# Altium schematic sheet Amp_op3.SchDoc(Amp_op3)
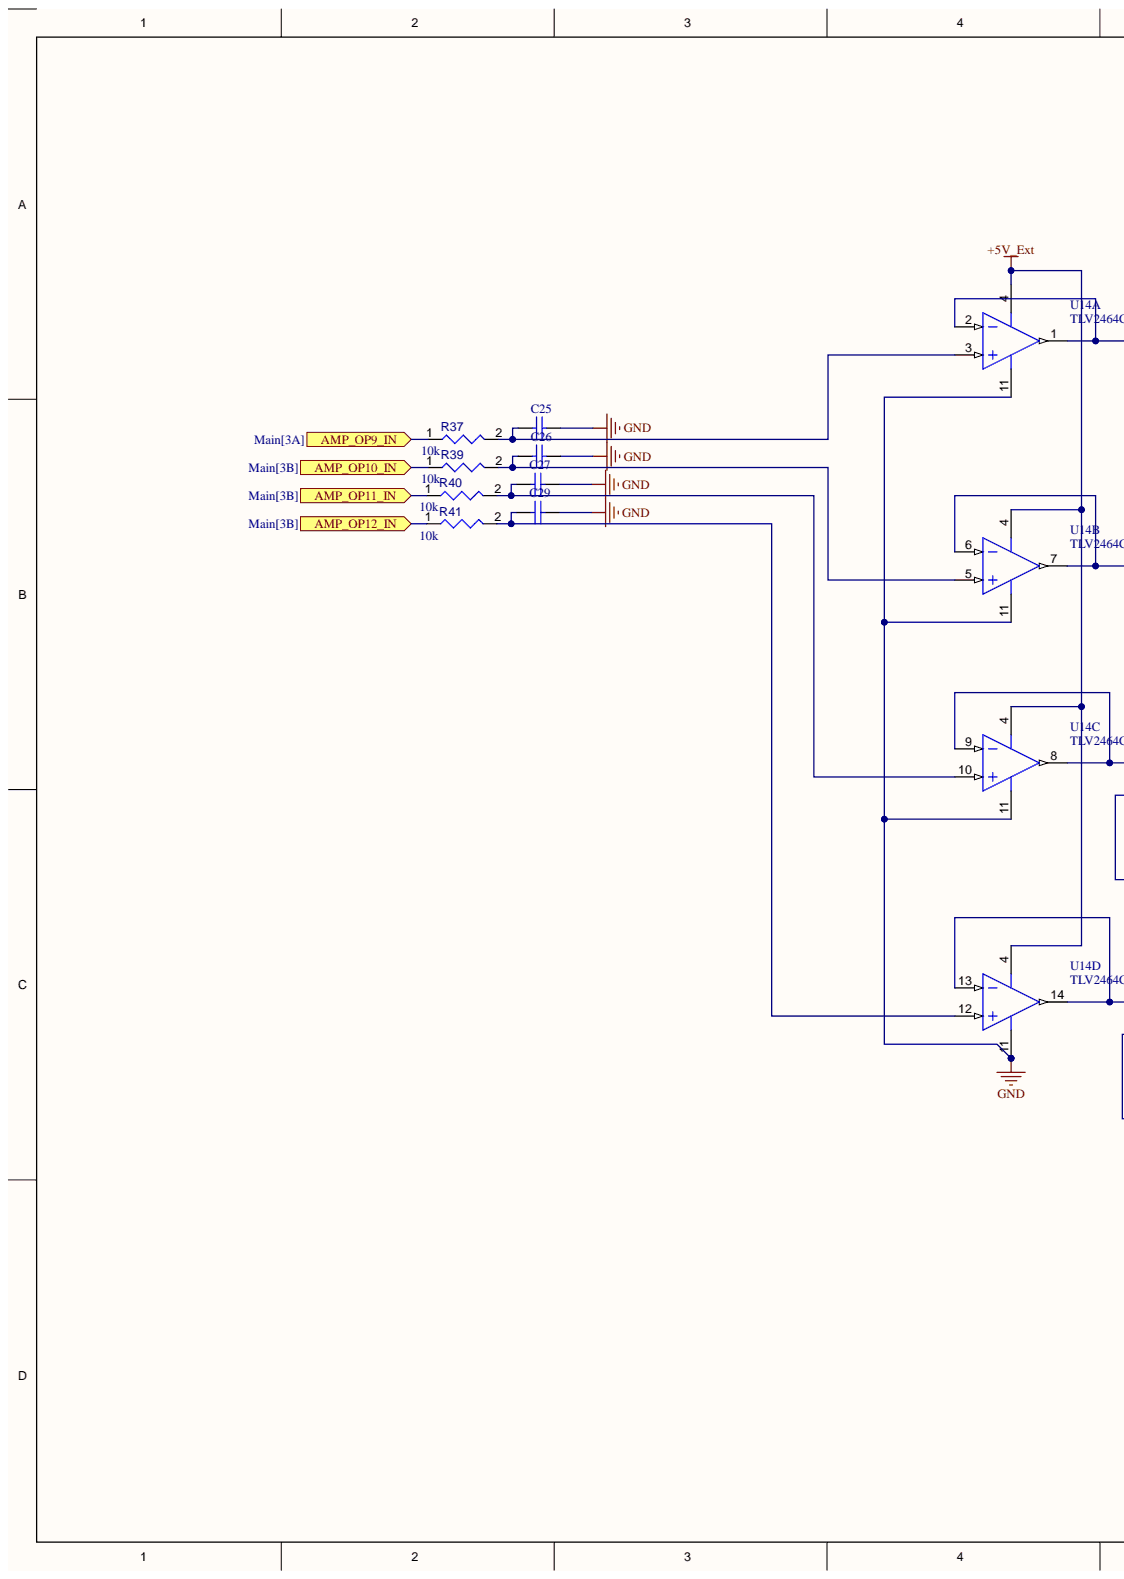
[diagram p0: Amp_op3.SchDoc, left]
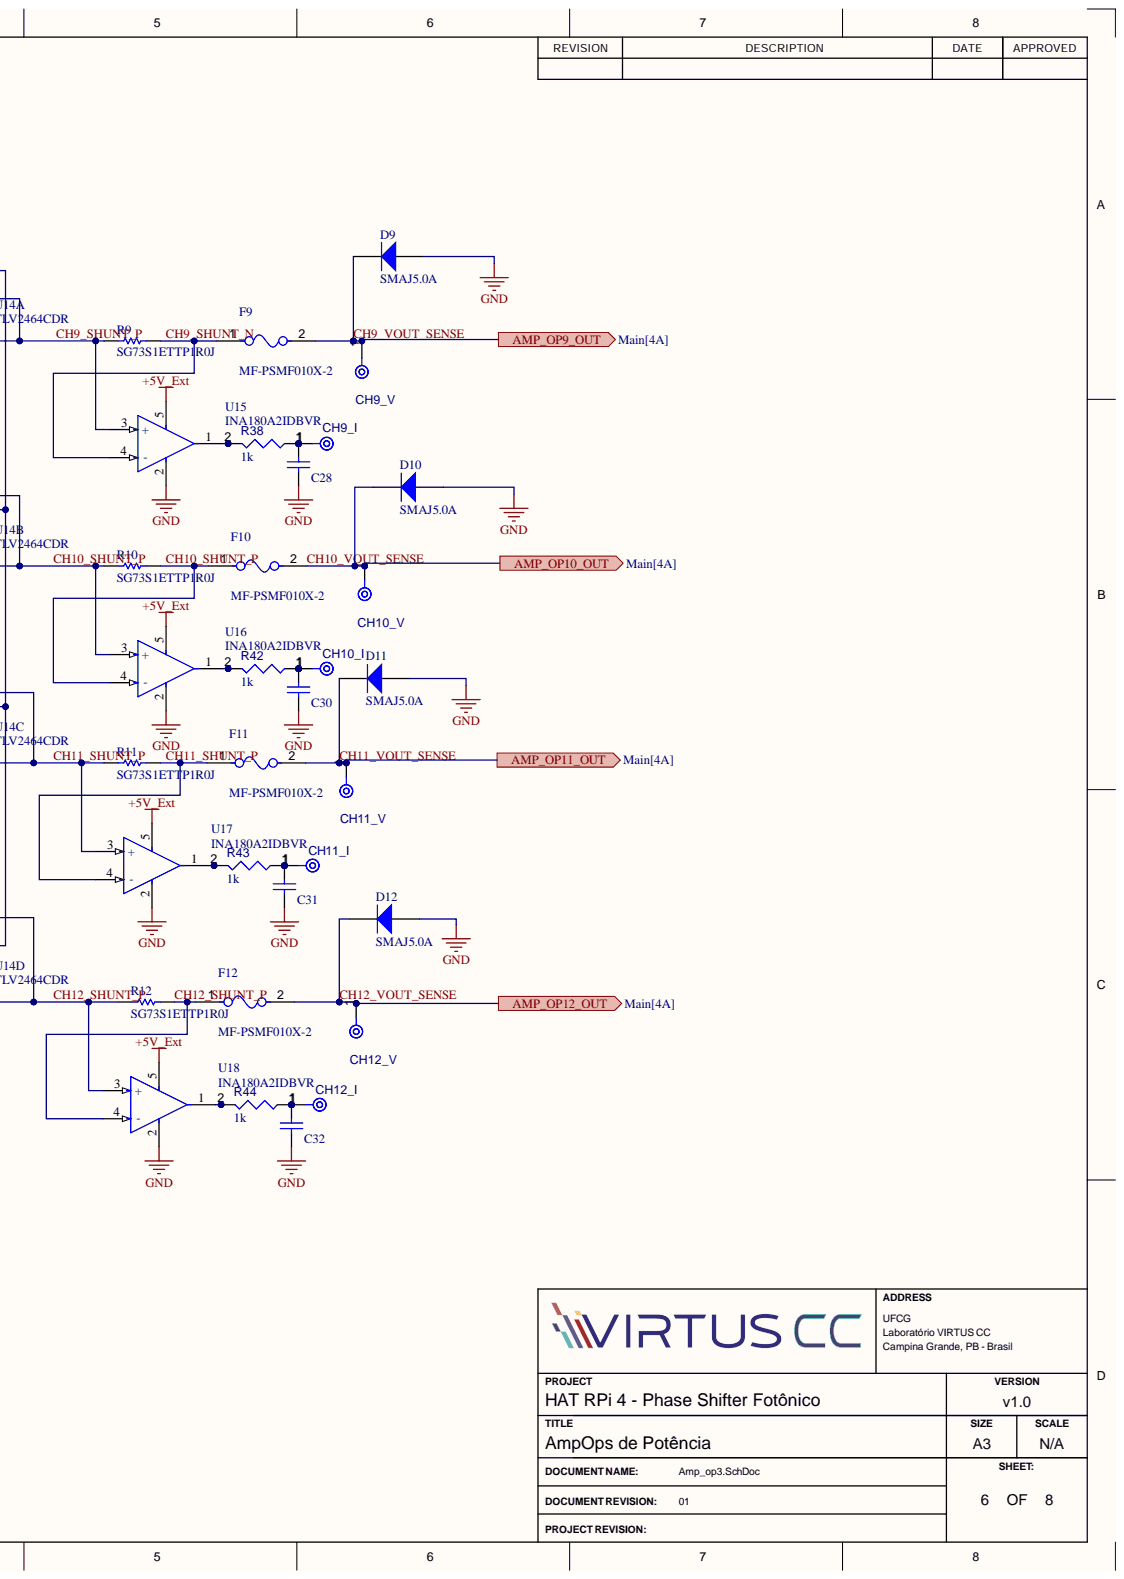
[diagram p1: Amp_op3.SchDoc, right]

## Parts
C25  VJ0603Y104MXJCW1BC  [CAPC1608X95X40NL15T15]  (value not drawn)
C26  VJ0603Y104MXJCW1BC  [CAPC1608X95X40NL15T15]  (value not drawn)
C27  VJ0603Y104MXJCW1BC  [CAPC1608X95X40NL15T15]  (value not drawn)
C28  VJ0603Y104MXJCW1BC  [CAPC1608X95X40NL15T15]  (value not drawn)
C29  VJ0603Y104MXJCW1BC  [CAPC1608X95X40NL15T15]  (value not drawn)
C30  VJ0603Y104MXJCW1BC  [CAPC1608X95X40NL15T15]  (value not drawn)
C31  VJ0603Y104MXJCW1BC  [CAPC1608X95X40NL15T15]  (value not drawn)
C32  VJ0603Y104MXJCW1BC  [CAPC1608X95X40NL15T15]  (value not drawn)
CH9_I  TPAD6R  [TP06R]  (value not drawn)  (no BOM)
CH9_V  TPAD6R  [TP06R]  (value not drawn)  (no BOM)
CH10_I  TPAD6R  [TP06R]  (value not drawn)  (no BOM)
CH10_V  TPAD6R  [TP06R]  (value not drawn)  (no BOM)
CH11_I  TPAD6R  [TP06R]  (value not drawn)  (no BOM)
CH11_V  TPAD6R  [TP06R]  (value not drawn)  (no BOM)
CH12_I  TPAD6R  [TP06R]  (value not drawn)  (no BOM)
CH12_V  TPAD6R  [TP06R]  (value not drawn)  (no BOM)
D9  SMAJ5.0A  [BOUR-SMA-2_V]
D10  SMAJ5.0A  [BOUR-SMA-2_V]
D11  SMAJ5.0A  [BOUR-SMA-2_V]
D12  SMAJ5.0A  [BOUR-SMA-2_V]
F9  MF-PSMF010X-2  [FP-MF-PSMF-MFG]
F10  MF-PSMF010X-2  [FP-MF-PSMF-MFG]
F11  MF-PSMF010X-2  [FP-MF-PSMF-MFG]
F12  MF-PSMF010X-2  [FP-MF-PSMF-MFG]
R9  SG73S1ETTP1R0J  [RESC1005X40X25LL10T10]
R10  SG73S1ETTP1R0J  [RESC1005X40X25LL10T10]
R11  SG73S1ETTP1R0J  [RESC1005X40X25LL10T10]
R12  SG73S1ETTP1R0J  [RESC1005X40X25LL10T10]
R37  value 10k  [RESC0603(1608)_L]  (hidden comment: Resistor 10k +/-1% 0603 100 mW)
R38  value 1k  [RESC0603(1608)_L]  (hidden comment: Resistor 1k +/-1% 0603 100 mW)
R39  value 10k  [RESC0603(1608)_L]  (hidden comment: Resistor 10k +/-1% 0603 100 mW)
R40  value 10k  [RESC0603(1608)_L]  (hidden comment: Resistor 10k +/-1% 0603 100 mW)
R41  value 10k  [RESC0603(1608)_L]  (hidden comment: Resistor 10k +/-1% 0603 100 mW)
R42  value 1k  [RESC0603(1608)_L]  (hidden comment: Resistor 1k +/-1% 0603 100 mW)
R43  value 1k  [RESC0603(1608)_L]  (hidden comment: Resistor 1k +/-1% 0603 100 mW)
R44  value 1k  [RESC0603(1608)_L]  (hidden comment: Resistor 1k +/-1% 0603 100 mW)
U14  TLV2464CDR  [D0014A_N]  (parts A,B,C,D)
U15  INA180A2IDBVR  [FP-DBV0005A-MFG]
U16  INA180A2IDBVR  [FP-DBV0005A-MFG]
U17  INA180A2IDBVR  [FP-DBV0005A-MFG]
U18  INA180A2IDBVR  [FP-DBV0005A-MFG]

## Ports
AMP_OP9_IN, AMP_OP9_OUT, AMP_OP10_IN, AMP_OP10_OUT, AMP_OP11_IN, AMP_OP11_OUT, AMP_OP12_IN, AMP_OP12_OUT

## Nets
+5V_Ext: U14.4 U15.5 U16.5 U17.5 U18.5
GND: C25.2 C26.2 C27.2 C28.2 C29.2 C30.2 C31.2 C32.2 D9.1 D10.1 D11.1 D12.1 U14.11 U15.2 U16.2 U17.2 U18.2
AMP_OP9_IN: R37.1 ; port AMP_OP9_IN
AMP_OP10_IN: R39.1 ; port AMP_OP10_IN
AMP_OP11_IN: R40.1 ; port AMP_OP11_IN
AMP_OP12_IN: R41.1 ; port AMP_OP12_IN
CH9_SHUNT_N: F9.1 R9.2 U15.4(-)
CH9_SHUNT_P: R9.1 U14.1 U14.2 U15.3(+)
CH9_VOUT_SENSE: CH9_V.1 D9.2 F9.2 ; port AMP_OP9_OUT
CH10_SHUNT_P: F10.1 R10.1 R10.2 U14.6 U14.7 U16.3(+) U16.4(-)
CH10_VOUT_SENSE: CH10_V.1 D10.2 F10.2 ; port AMP_OP10_OUT
CH11_SHUNT_P: F11.1 R11.1 R11.2 U14.8 U14.9 U17.3(+) U17.4(-)
CH11_VOUT_SENSE: CH11_V.1 D11.2 F11.2 ; port AMP_OP11_OUT
CH12_SHUNT_P: F12.1 R12.1 R12.2 U14.13 U14.14 U18.3(+) U18.4(-)
CH12_VOUT_SENSE: CH12_V.1 D12.2 F12.2 ; port AMP_OP12_OUT
NetC25_1: C25.1 R37.2 U14.3
NetC26_1: C26.1 R39.2 U14.5
NetC27_1: C27.1 R40.2 U14.10
NetC28_1: C28.1 CH9_I.1 R38.1
NetC29_1: C29.1 R41.2 U14.12
NetC30_1: C30.1 CH10_I.1 R42.1
NetC31_1: C31.1 CH11_I.1 R43.1
NetC32_1: C32.1 CH12_I.1 R44.1
NetR38_2: R38.2 U15.1
NetR42_2: R42.2 U16.1
NetR43_2: R43.2 U17.1
NetR44_2: R44.2 U18.1

## Library data of the parts
C25-C32 = CMP-2006-00058-1: Manufacturer: Vitramon; Component Kind: Standard; Library Name: Altium Content Vault; Part Number: VJ0603Y104MXJCW1BC
CH9_I CH9_V CH10_I CH10_V CH11_I CH11_V CH12_I CH12_V = CMP-00028-00002-2: 0.6mm round; Component Type: Mechanical; Pins: 1; Library Name: PCEIOT.SCHLIB
D9-D12 = CMP-2000-07090-1: SMAJ Transient Voltage Suppressor Diode Series, 3.5 V, -55 to 150 degC, 2-Pin SMA, RoHS, Tape and Reel; Manufacturer: Bourns; Catalog Drawings: SMAJSeriesFootprintSMAJ,SMBJSeries; Current - Peak Pulse (10/1000µs): 43.5A; EDA / CAD Models: DownloadfromAcceleratedDesigns; Featured Product: SMAJ/SMBJ/SMCJ/SMLJDiscreteTVSDiodes; Online Catalog: SMAJSeries; Other Names: SMAJ5.0ABDKR; Power - Peak Pulse: 400W; Power Line Protection: No; Type: Zener; Unidirectional Channels: 1; Voltage - Breakdown (Min): 6.4V; Voltage - Clamping (Max) @ Ipp: 9.2V; Voltage - Reverse Standoff (Typ): 5V; Applications: GeneralPurpose; Bidirectional Channels: -; Capacitance @ Frequency: -; Category: CircuitProtection; Component Kind: Standard; Datasheet URL: https://datasheet.ciiva.com/25624/0900766b8112213b-25624680.pdf; Datasheet Version: 08/2012; Family: TVS-Diodes; Library Name: Altium Content Vault; Manufacturer URL: http://www.bourns.com/; Mounting Technology: SurfaceMount; Mounting Type: SurfaceMount; Operating Temperature: -55°C~150°C(TJ); Package / Case: DO-214AC,SMA; Package Description: 2-Pin Surface Mount Device, Body 4.315 x 2.605 mm; Package Reference: SMA-2; Packaging: Digi-Reel®; RoHS Information: SMAJSeriesMaterialDeclaration; Series: SMAJ; Standard Package: 1; Supplier Device Package: SMA(DO-214AC)
F9-F12 = CMP-07400-000017-1: PTC RESET FUSE 15V 100MA 0805; Manufacturer: Bourns Inc.; Current Rating: 40A; Hold Current: 0.1A; Resistance: 1-7.5Ω; Trip Current: 0.3A; Trip Time: 1.5s; Value: 1-7.5Ω; Ambient Temperature Range High: +85°C; Ambient Temperature Range Low: -40°C; Automotive: No; Category: Fuse; Component Kind: Standard; ComponentLink2Description: Datasheet; ComponentLink2URL: https://www.bourns.com/docs/Product-Datasheets/mfpsmf.pdf; Device Class L1: Circuit Protection; Device Class L2: PTC Resettable Fuses; Device Class L3: unset; Digikey Description: PTC RESET FUSE 15V 100MA 0805; Height: 0.85mm; Ipc Land Pattern Name: CAPC215135X67; Lead Free: Yes; Library Name: Altium Content Vault; Manufacturer Part Number: MF-PSMF010X-2; Mouser Description: Resettable Fuses - PPTC 0.10A 15V 1ohm; Octopart: https://octopart.com/mf-psmf010x-2-bourns-7483441; Package: MF-PSMF; RoHS: TRUE; Temperature: -40°C to +85°C; Temperature Range High: +85°C; Temperature Range Low: -40°C; Voltage Rating: 15V
R9-R12 = CMP-2002-04124-1: Manufacturer: KOA Speer; Component Kind: Standard; Library Name: Altium Content Vault; Part Number: SG73S1ETTP1R0J
R37 R39-R41 = CMP-009-00017-7: Chip Resistor, 10 KOhm, +/- 1%, 01 W, -55 to 155 degC, 0603 (1608 Metric); Manufacturer: Vishay; Manufacturer Part Number: CRCW060310K0FKEA; Power: 100mW; Voltage Rating: 50V; Case/Package: 0603; Component Kind: Standard; Component Type: Resistors; Max Operating Temperature: 155°C; Min Operating Temperature: -55°C; Mounting Technology: SM; Pins: 2; RoHS Compliant: True; Tolerance: 1%; Library Name: PCEIOT.SCHLIB
R38 R42-R44 = CMP-009-00014-6: Chip Resistor, 1 KOhm, +/-1%, 0.1 W, -55 to 155 degC, 0603 (1608 Metric); Manufacturer: Yageo; Manufacturer Part Number: RC0603FR-131KL; Power: 100mW; Tolerance: 1%; Voltage Rating: 50V; Case/Package: 0603; Component Kind: Standard; Component Type: Resistors; Max Operating Temperature: 155°C; Min Operating Temperature: -55°C; Mounting Technology: SM; Pins: 2; RoHS Compliant: True; Library Name: PCEIOT.SCHLIB
U14 = CMP-0271-00006-3: Quad Low Power, Rail-to-Rail Input / Output Operational Amplifier, 2.7 to 6 V, 0 to 70 degC, 14-pin SOIC (D14), Green (RoHS & no Sb/Br); Manufacturer: Texas Instruments; CMRR(Min)(dB): 66; IIB(Max)(pA): 14000; Iq per channel(Max)(mA): 0.575; Number of Channels: 4; Offset Drift(Typ)(uV/C): 2; Slew Rate(Typ)(V/us): 1.6; Sub Family: High Output Current Amplifier (>=50mA), Low Supply Voltage Amplifier (<=2.7V), Precision Amplifier; Total Supply Voltage(Max)(+5V is 5, +/-5V is 10): 6; Total Supply Voltage(Min)(+5V is 5, +/-5V is 10): 2.7; Vn at 1kHz(Typ)(nV/rtHz): 11; Code_JEDEC: MS-012; Component Kind: Standard; ComponentLink1Description: Manufacturer URL; ComponentLink1URL: http://www.ti.com/; ComponentLink2Description: Datasheet; ComponentLink2URL: http://www.ti.com/general/docs/lit/getliterature.tsp?genericPartNumber=TLV2464&fileType=pdf; ComponentLink3Description: Package Specification; ComponentLink3URL: http://www.ti.com/lit/ml/mpds177g/mpds177g.pdf; DatasheetVersion: SLOS220J; Eco-Plan: Green (RoHS & no Sb/Br); Library Name: Altium Content Vault; Mounting Technology: Surface Mount; PackageDescription: 14-Pin Plastic Small Outline, Body 8.65 x 3.9 mm, Pitch 1.27 mm; PackageReference: D0014A; PackageVersion: 4040047-5/M, 06/2011; PartNumber: TLV2464CDR; Vio (25C)(Max)(mV): 2
U15-U18 = CMP-04895-000287-1: IC CURR SENSE 1 CIRCUIT SOT23-5; -3db Bandwidth: 350kHz; Input Bias Current: 100nA; Input Offset Voltage (Vos): 100uV; Number of Circuits: 1; Operating Supply Current: 260uA; Slew Rate: 2V/µs; Case/Package: SOT-23-5; Component Kind: Standard; Library Name: Altium Content Vault; Max Operating Temperature: 125°C; Min Operating Temperature: -40°C; Mount: Surface Mount; Packaging: Tape and Reel; RoHS Compliant: Yes
